# Altium schematic sheet RAM.SchDoc
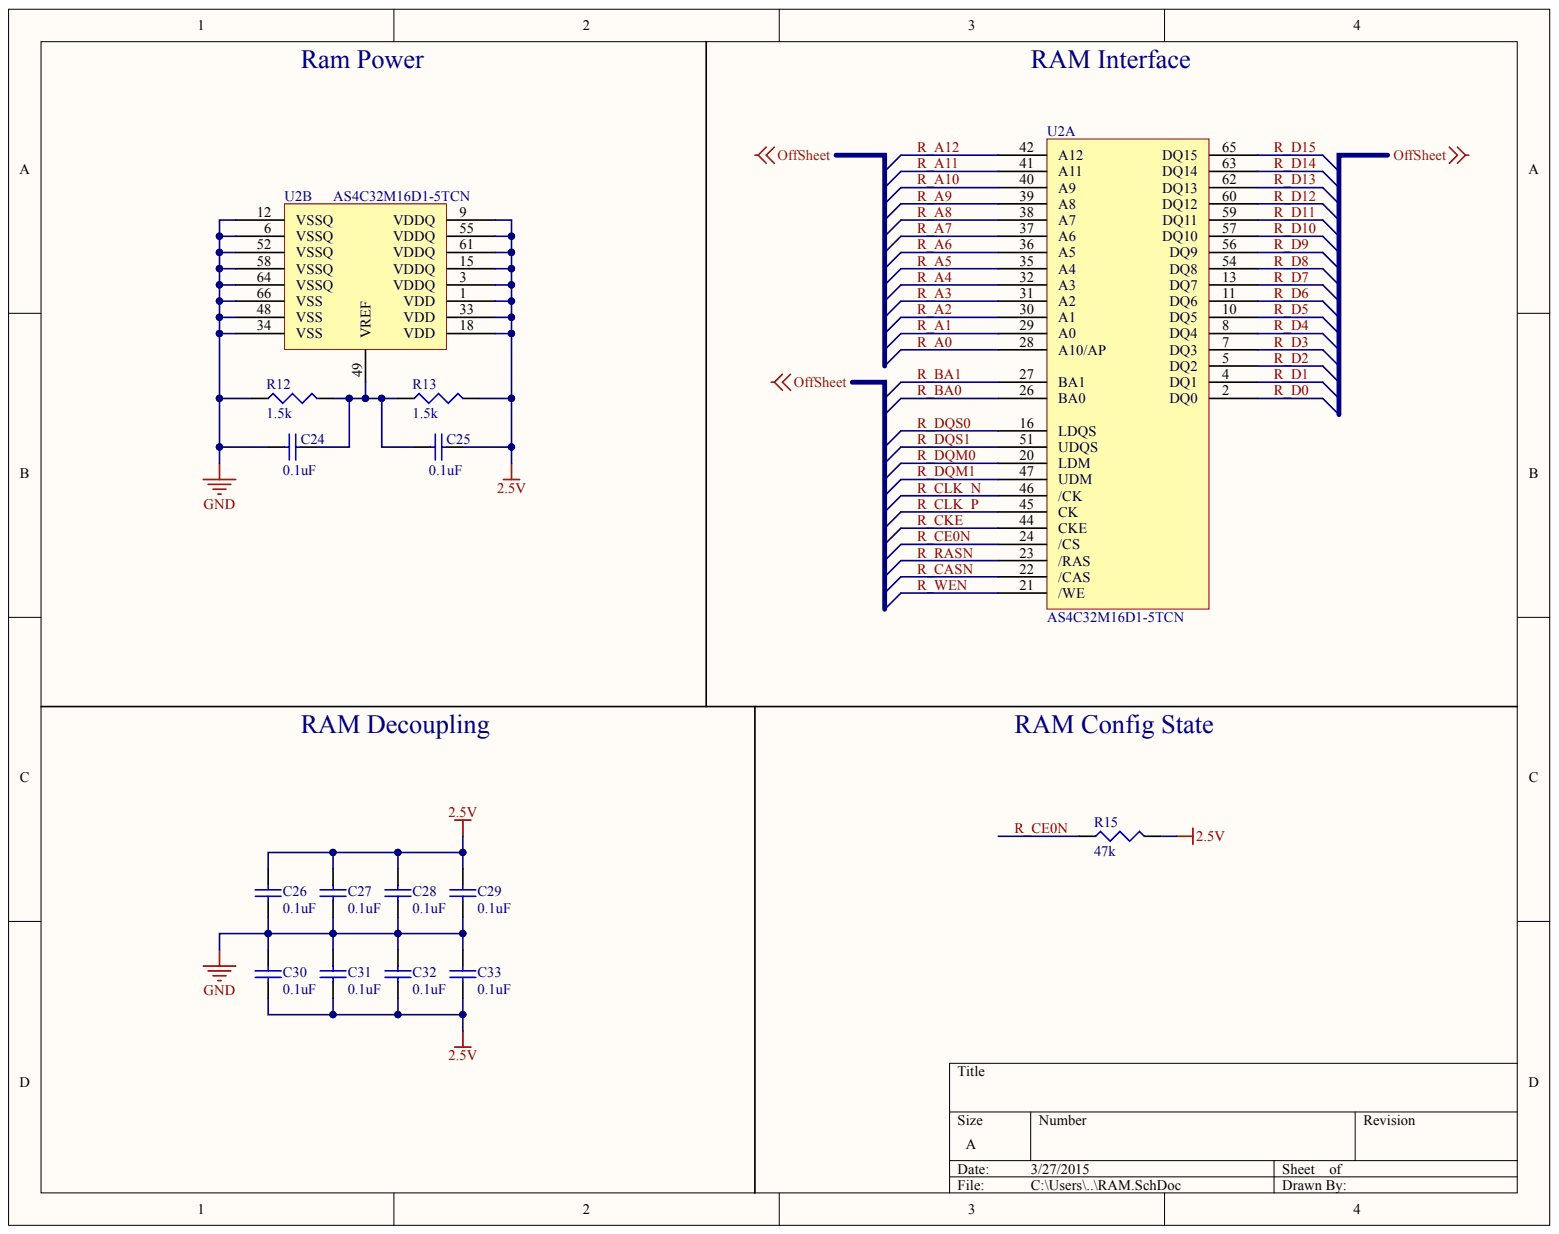
[diagram p0: RAM.SchDoc]

## Parts
C24  value 0.1uF  [0402]  (hidden comment: CL05B104KQ5NNNC)
C25  value 0.1uF  [0402]  (hidden comment: CL05B104KQ5NNNC)
C26  value 0.1uF  [0402]  (hidden comment: CL05B104KQ5NNNC)
C27  value 0.1uF  [0402]  (hidden comment: CL05B104KQ5NNNC)
C28  value 0.1uF  [0402]  (hidden comment: CL05B104KQ5NNNC)
C29  value 0.1uF  [0402]  (hidden comment: CL05B104KQ5NNNC)
C30  value 0.1uF  [0402]  (hidden comment: CL05B104KQ5NNNC)
C31  value 0.1uF  [0402]  (hidden comment: CL05B104KQ5NNNC)
C32  value 0.1uF  [0402]  (hidden comment: CL05B104KQ5NNNC)
C33  value 0.1uF  [0402]  (hidden comment: CL05B104KQ5NNNC)
R12  value 1.5k  [J1-0603]  (hidden comment: MCT06030C1501FP500)
R13  value 1.5k  [J1-0603]  (hidden comment: MCT06030C1501FP500)
R15  value 47k  [J1-0603]  (hidden comment: MCR03ERTF4702)
U2  AS4C32M16D1-5TCN  [TSOP65P1180X120-66N]  (parts A,B)

## Nets
2.5V: C25.1 C26.1 C27.1 C28.1 C29.1 C30.2 C31.2 C32.2 C33.2 R13.2 R15.2 U2.1(VDD) U2.3(VDDQ) U2.9(VDDQ) U2.15(VDDQ) U2.18(VDD) U2.33(VDD) U2.55(VDDQ) U2.61(VDDQ)
GND: C24.2 C26.2 C27.2 C28.2 C29.2 C30.1 C31.1 C32.1 C33.1 R12.1 U2.6(VSSQ) U2.12(VSSQ) U2.34(VSS) U2.48(VSS) U2.52(VSSQ) U2.58(VSSQ) U2.64(VSSQ) U2.66(VSS)
R_A0: U2.28(A10/AP)
R_A1: U2.29(A0)
R_A2: U2.30(A1)
R_A3: U2.31(A2)
R_A4: U2.32(A3)
R_A5: U2.35(A4)
R_A6: U2.36(A5)
R_A7: U2.37(A6)
R_A8: U2.38(A7)
R_A9: U2.39(A8)
R_A10: U2.40(A9)
R_A11: U2.41(A11)
R_A12: U2.42(A12)
R_BA0: U2.26(BA0)
R_BA1: U2.27(BA1)
R_CASN: U2.22(/CAS)
R_CE0N: R15.1 U2.24(/CS)
R_CKE: U2.44(CKE)
R_CLK_N: U2.46(/CK)
R_CLK_P: U2.45(CK)
R_D0: U2.2(DQ0)
R_D1: U2.4(DQ1)
R_D2: U2.5(DQ2)
R_D3: U2.7(DQ3)
R_D4: U2.8(DQ4)
R_D5: U2.10(DQ5)
R_D6: U2.11(DQ6)
R_D7: U2.13(DQ7)
R_D8: U2.54(DQ8)
R_D9: U2.56(DQ9)
R_D10: U2.57(DQ10)
R_D11: U2.59(DQ11)
R_D12: U2.60(DQ12)
R_D13: U2.62(DQ13)
R_D14: U2.63(DQ14)
R_D15: U2.65(DQ15)
R_DQM0: U2.20(LDM)
R_DQM1: U2.47(UDM)
R_DQS0: U2.16(LDQS)
R_DQS1: U2.51(UDQS)
R_RASN: U2.23(/RAS)
R_WEN: U2.21(/WE)
NetC24_1: C24.1 C25.2 R12.2 R13.1 U2.49(VREF)
N00114:
N00115:
N00116:
OFFSHEET:

## Library data of the parts
C24-C33 = CL05B104KQ5NNNC: Cap Ceramic 0.1uF 6.3V X7R 10% SMD 0402; Component Kind: Standard; LatestRevisionDate: 29-May-2009; LatestRevisionNote: IPC-7351 Footprint Added.; PackageDescription: Chip Capacitor; PackageReference: C1206; Published: 8-Jun-2000; Signal Integrity: Cap Semi; Simulation: CAP; Supplier 1: Arrow; Supplier 2: Digi-Key; Supplier Part Number 1: c0Ft5huTF1PnwzXLA59QPYxRs3E3aghew1LSAii7cAMZVywxIBNyoAQstvnyfZZ0; Supplier Part Number 2: 1276-1511-1-ND; Library Name: Linux_Board_SCHEM_LIB.SchLib; Publisher: Altium Limited
R12 R13 = MCT06030C1501FP500: RES SMD 1.5K OHM 1% 1/8W 0603; Component Kind: Standard; LatestRevisionDate: 29-May-2009; LatestRevisionNote: IPC-7351 Footprint Added.; PackageDescription: Chip Resistor; PackageReference: J1-0603; Published: 8-Jun-2000; Signal Integrity: Res; Simulation: RESISTOR; Supplier 1: Digi-Key; Supplier Part Number 1: MCT0603-1.50K-CFCT-ND; Library Name: Linux_Board_SCHEM_LIB.SchLib; Publisher: Altium Limited
R15 = MCR03ERTF4702: RES SMD 47K OHM 1% 1/10W 0603; Component Kind: Standard; LatestRevisionDate: 29-May-2009; LatestRevisionNote: IPC-7351 Footprint Added.; PackageDescription: Chip Resistor; PackageReference: J1-0603; Published: 8-Jun-2000; Signal Integrity: Res; Simulation: RESISTOR; Supplier 1: Digi-Key; Supplier Part Number 1: RHM47.0KCFCT-ND; Library Name: Linux_Board_SCHEM_LIB.SchLib; Publisher: Altium Limited
U2 = AS4C32M16D1-5TCN: IC DDR SDRAM 512MBIT 66TSOP; Component Kind: Standard; Supplier 1: Digi-Key; Supplier Part Number 1: 1450-1006-ND; Library Name: Linux_Board_SCHEM_LIB.SchLib
